# Altium schematic sheet key、buzzer.SchDoc(key、buzzer)
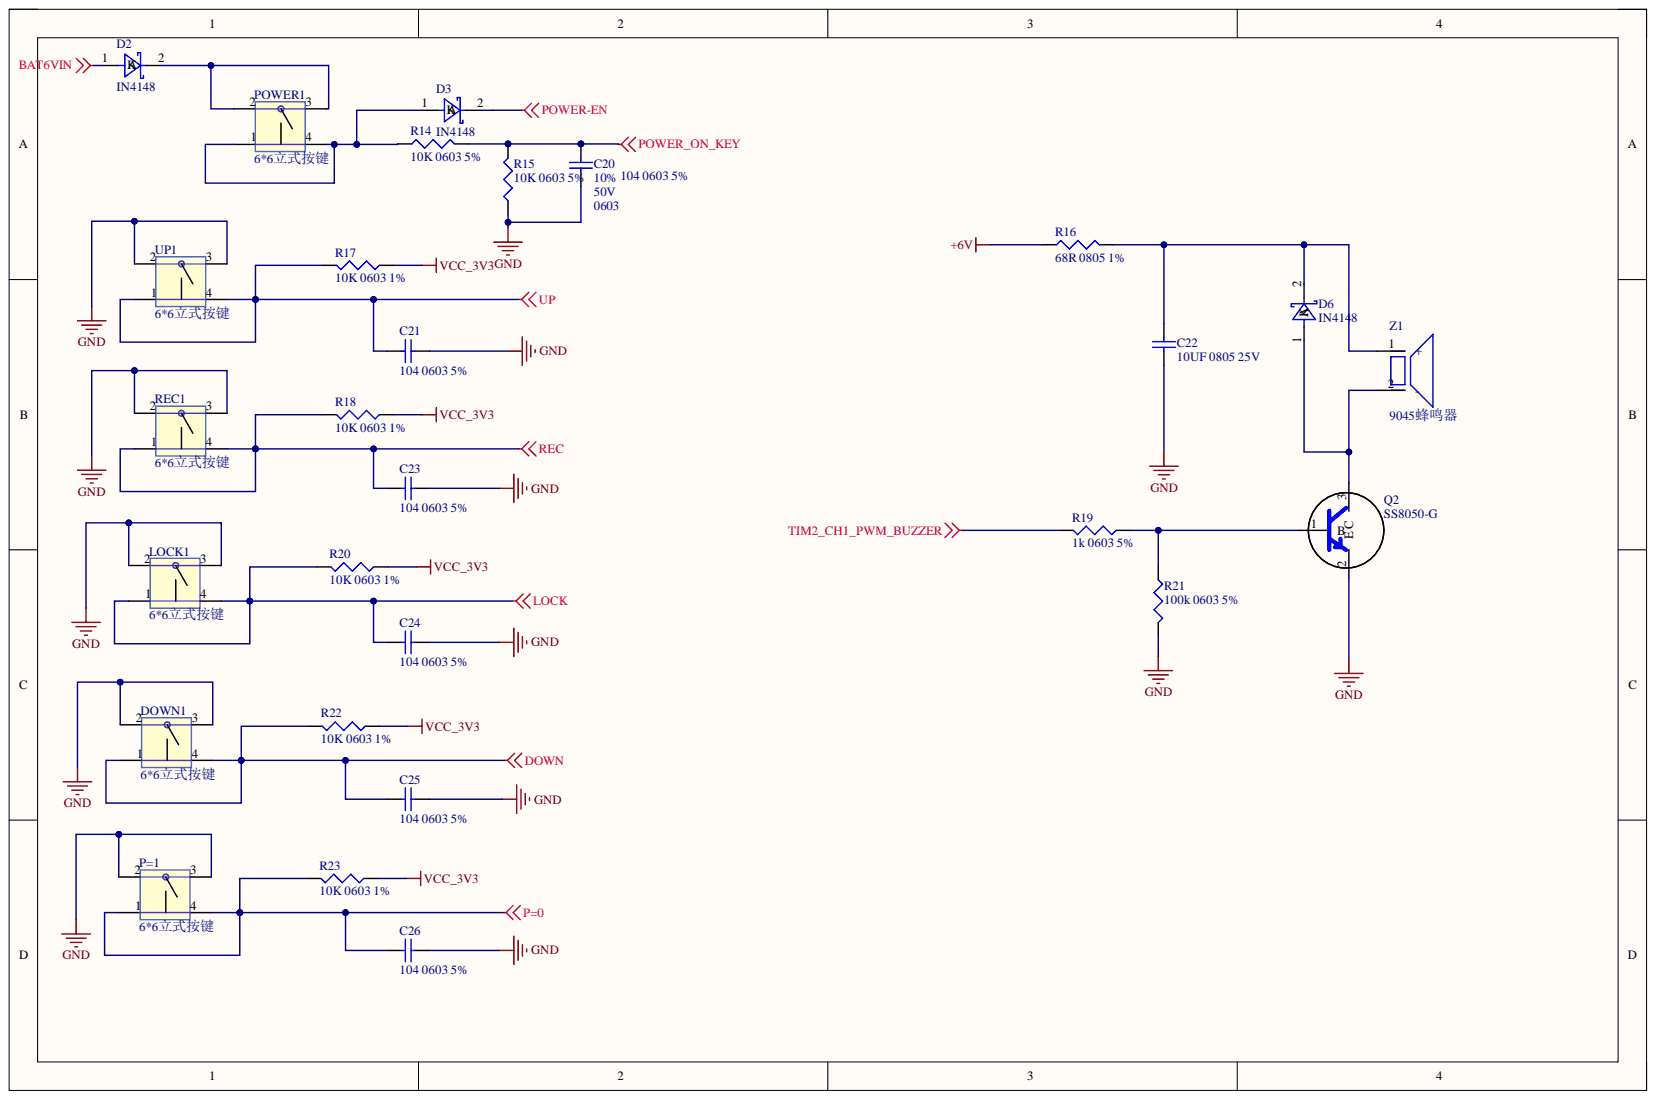
[diagram p0: key、buzzer.SchDoc]

## Parts
C20  104 0603 5%  容值误差: 10%  封装: 0603  耐压: 50V  [CAP0603_3D]
C21  104 0603 5%  封装: 0603  [CAP0603_3D]
C22  10UF 0805 25V  [CAP0805-3D]
C23  104 0603 5%  封装: 0603  [CAP0603_3D]
C24  104 0603 5%  封装: 0603  [CAP0603_3D]
C25  104 0603 5%  封装: 0603  [CAP0603_3D]
C26  104 0603 5%  封装: 0603  [CAP0603_3D]
D2  IN4148  [SOD-123]
D3  IN4148  [SOD-123]
D6  IN4148  [LL34]
DOWN1  6*6立式按键  [6*6贴片立式按键]
LOCK1  6*6立式按键  [6*6贴片立式按键]
P=1  6*6立式按键  [6*6贴片立式按键]
POWER1  6*6立式按键  [6*6贴片立式按键]
Q2  SS8050-G  [SOT23]
R14  10K 0603 5%  [RES0603_3D]
R15  10K 0603 5%  [RES0603_3D]
R16  68R 0805 1%  精度误差: 1%  [RES0603_3D]
R17  10K 0603 1%  精度误差: 1%  [RES0603_3D]
R18  10K 0603 1%  精度误差: 1%  [RES0603_3D]
R19  1k 0603 5%  [RES0603_3D]
R20  10K 0603 1%  精度误差: 1%  [RES0603_3D]
R21  100k 0603 5%  [RES0603_3D]
R22  10K 0603 1%  精度误差: 1%  [RES0603_3D]
R23  10K 0603 1%  精度误差: 1%  [RES0603_3D]
REC1  6*6立式按键  [6*6贴片立式按键]
UP1  6*6立式按键  [6*6贴片立式按键]
Z1  9045蜂鸣器  [9045贴片蜂鸣器]

## Nets
+6V: R16.1
BAT6VIN: D2.1
DOWN: C25.2 DOWN1.1 DOWN1.4 R22.2
GND: C20.2 C21.1 C22.2 C23.1 C24.1 C25.1 C26.1 DOWN1.2 DOWN1.3 LOCK1.2 LOCK1.3 P=1.2 P=1.3 Q2.2 R15.1 R21.2 REC1.2 REC1.3 UP1.2 UP1.3
LOCK: C24.2 LOCK1.1 LOCK1.4 R20.2
P=0: C26.2 P=1.1 P=1.4 R23.2
POWER-EN: D3.2
POWER_ON_KEY: C20.1 R14.2 R15.2
REC: C23.2 R18.2 REC1.1 REC1.4
TIM2_CH1_PWM_BUZZER: R19.2
UP: C21.2 R17.2 UP1.1 UP1.4
VCC_3V3: R17.1 R18.1 R20.1 R22.1 R23.1
NetC22_1: C22.1 D6.2 R16.2 Z1.1
NetD2_2: D2.2 POWER1.2 POWER1.3
NetD3_1: D3.1 POWER1.1 POWER1.4 R14.1
NetD6_1: D6.1 Q2.3 Z1.2
NetQ2_1: Q2.1 R19.1 R21.1

## Library data of the parts
C20 = CAP_SMT: 贴片多层陶瓷电容; Component Kind: Standard; Library Name: hwt.SchLib
C21 C23-C26 = CAP_SMT: 贴片多层陶瓷电容; 容值误差: 10%; 耐压: 50V; Component Kind: Standard; Library Name: hwt.SchLib
C22 = CAP_SMT: 贴片多层陶瓷电容; 容值误差: 10%; 封装: 0603; 耐压: 50V; Component Kind: Standard; Library Name: hwt.SchLib
R14 R15 R19 R21 = RES_SMT: 精度误差: 1%; Component Kind: Standard; Library Name: hwt.SchLib
R16-R18 R20 R22 R23 = RES_SMT: Component Kind: Standard; Library Name: hwt.SchLib
D2 D3 D6 = IN4148: Component Kind: Standard; Library Name: hhh.SCHLIB
DOWN1 LOCK1 P=1 POWER1 REC1 UP1 = 6*6立式按键: Component Kind: Standard; Library Name: hwt.SchLib
Q2 = SS8050-G: Component Kind: Standard; Library Name: hwt.SchLib
Z1 = 9045蜂鸣器: Component Kind: Standard; Library Name: hwt.SchLib
